# Altium schematic sheet HIGHSPEED_v0_NM.SchDoc
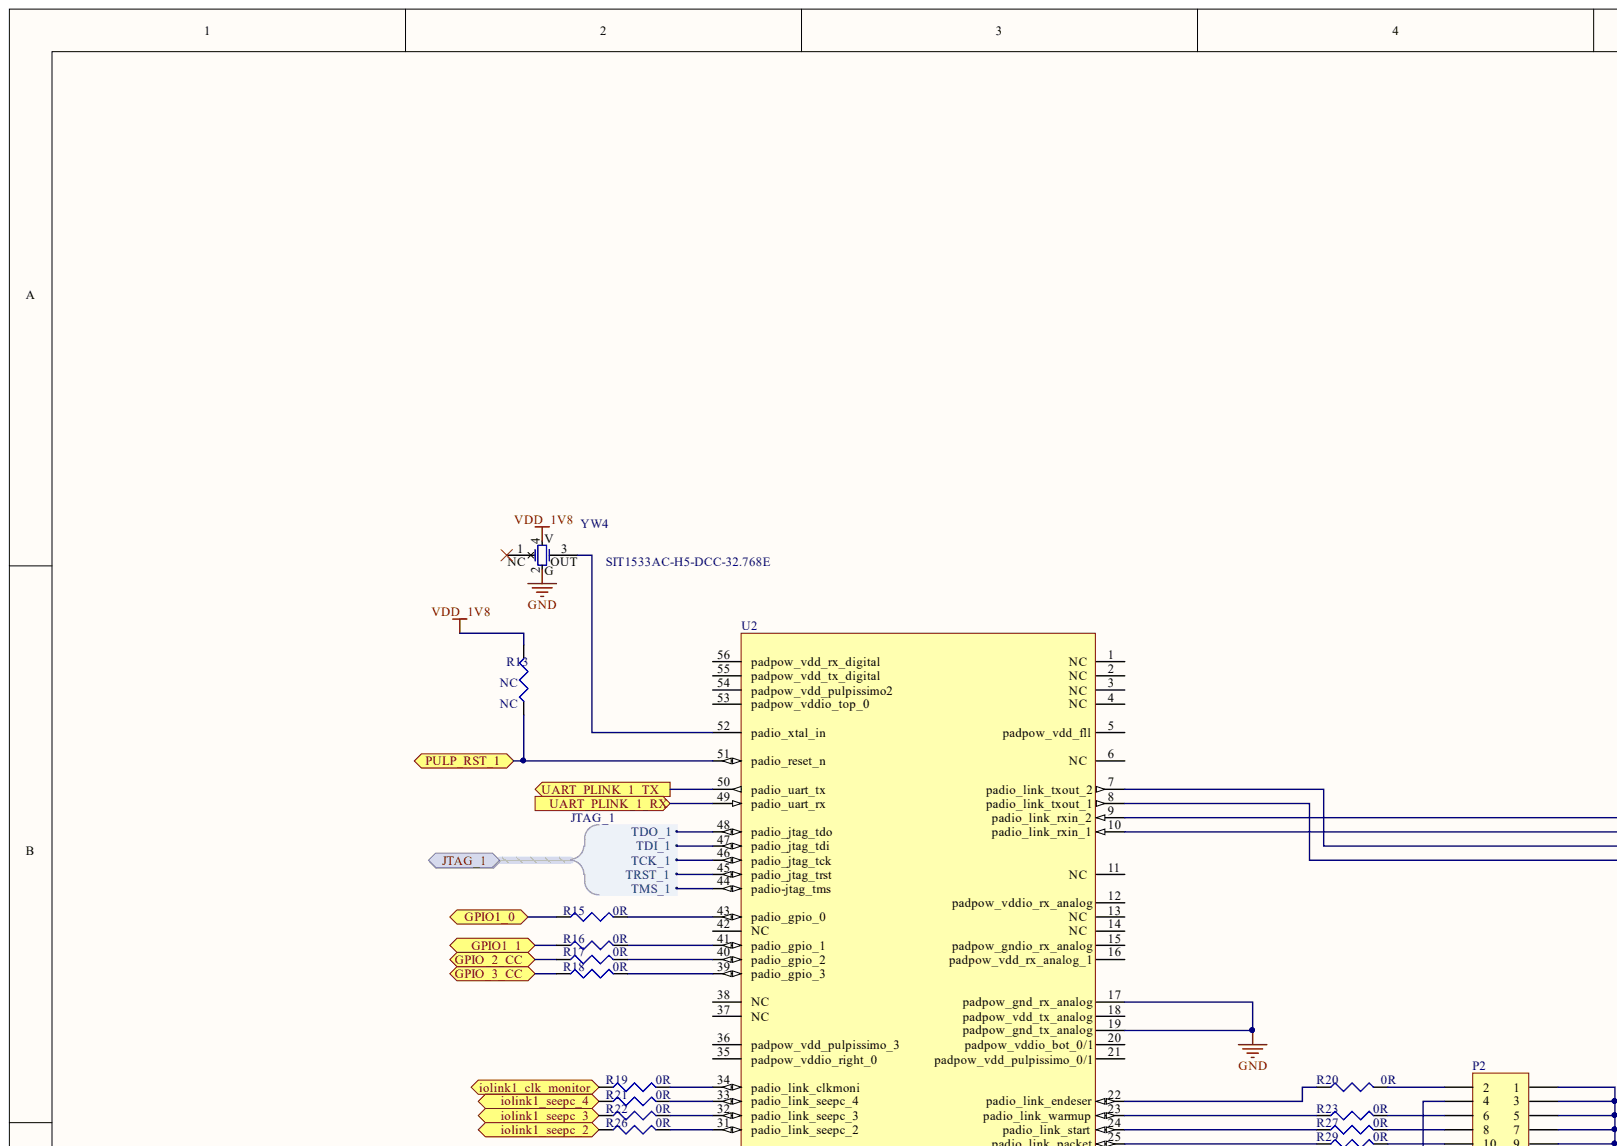
[diagram p0: HIGHSPEED_v0_NM.SchDoc, top left]
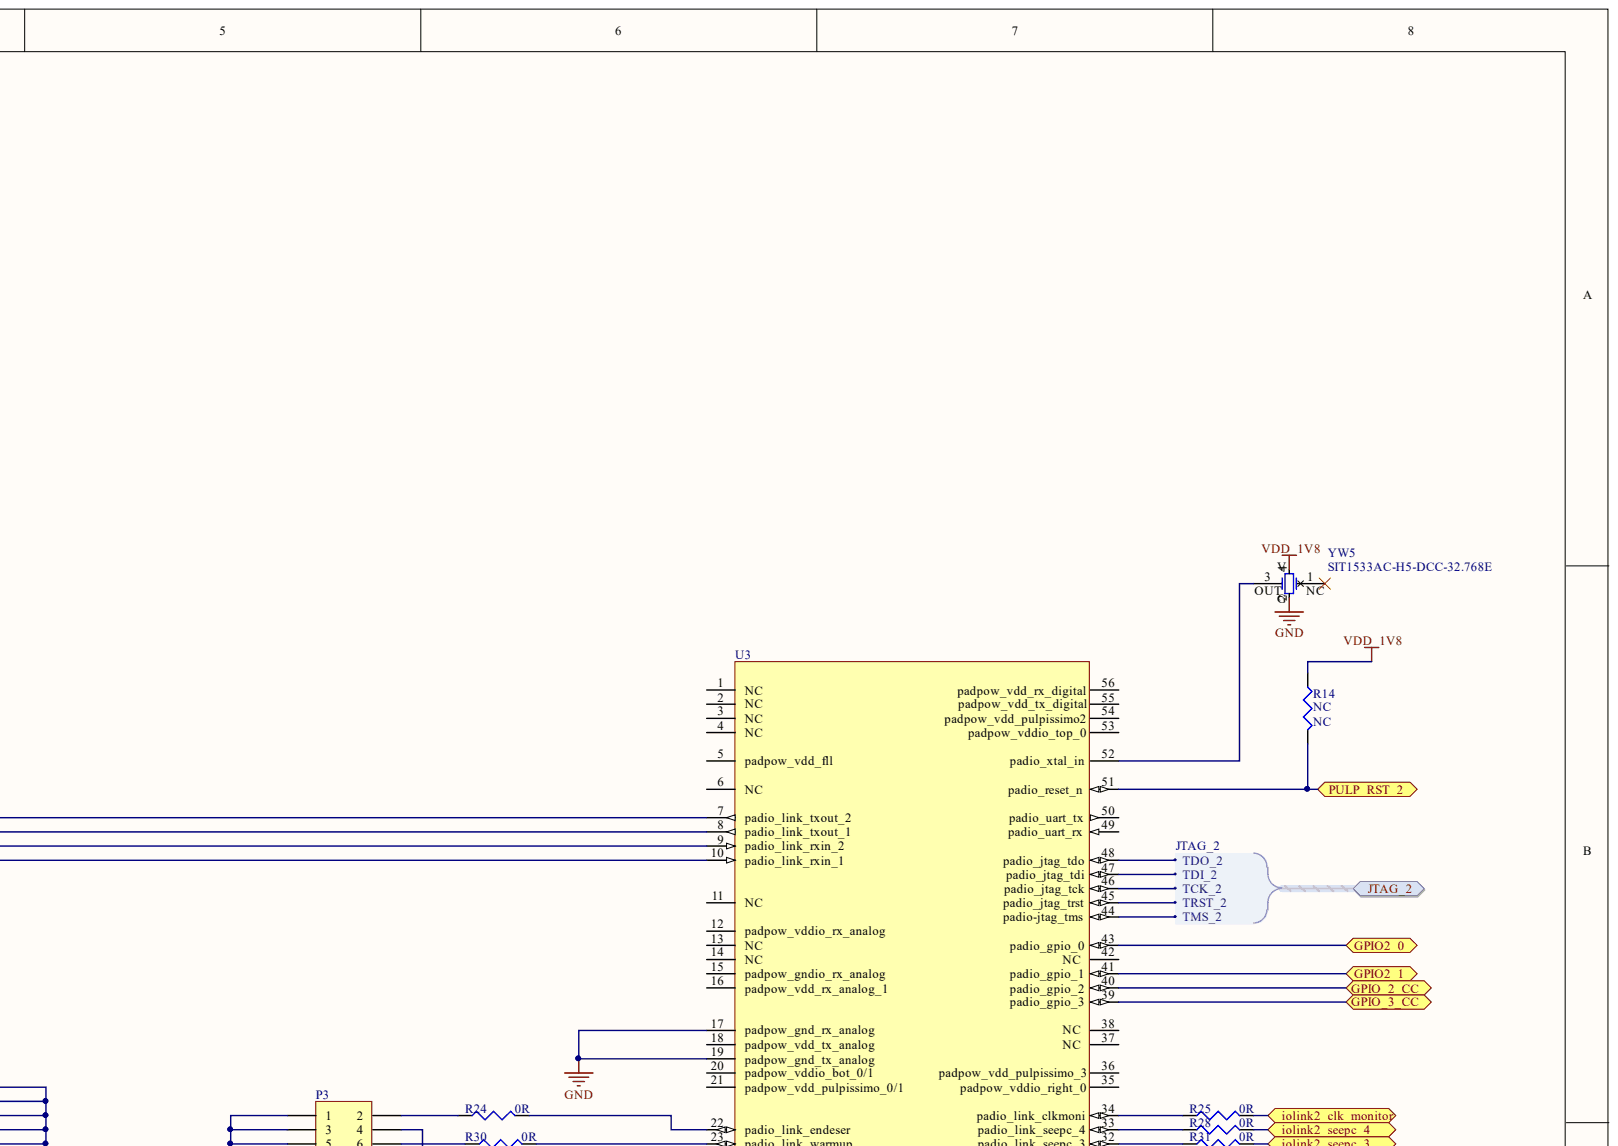
[diagram p1: HIGHSPEED_v0_NM.SchDoc, top right]
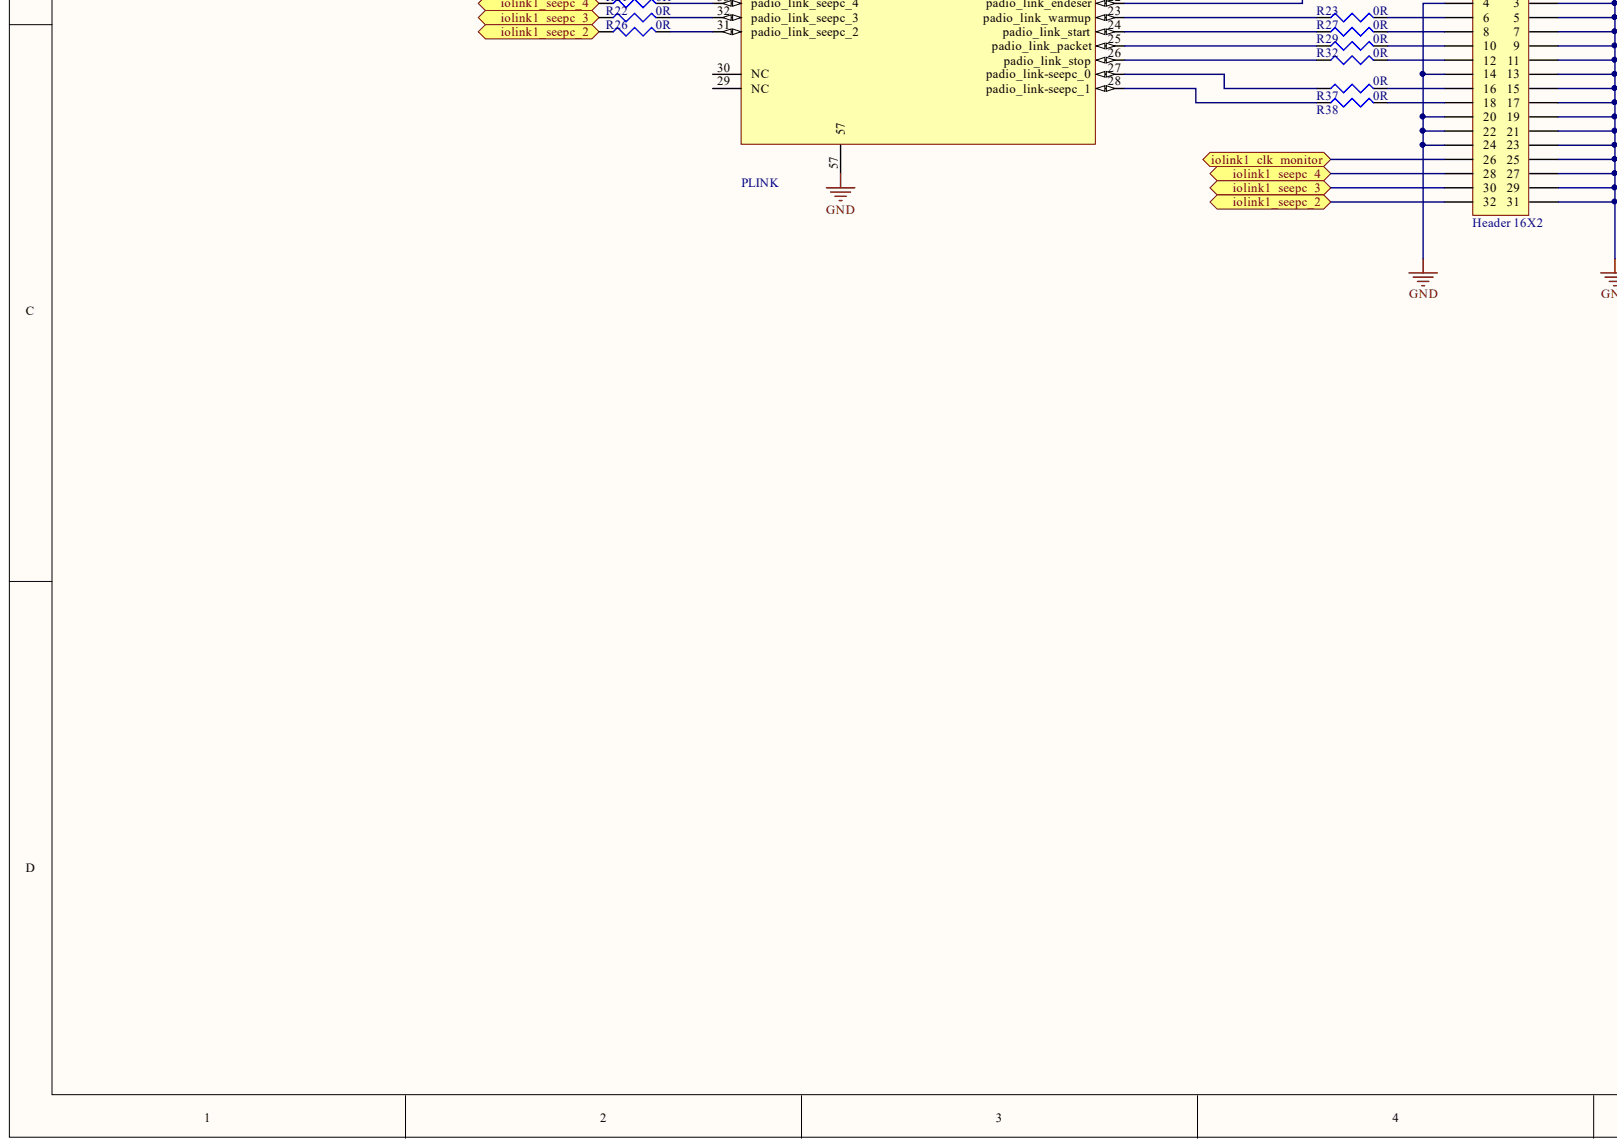
[diagram p2: HIGHSPEED_v0_NM.SchDoc, bottom left]
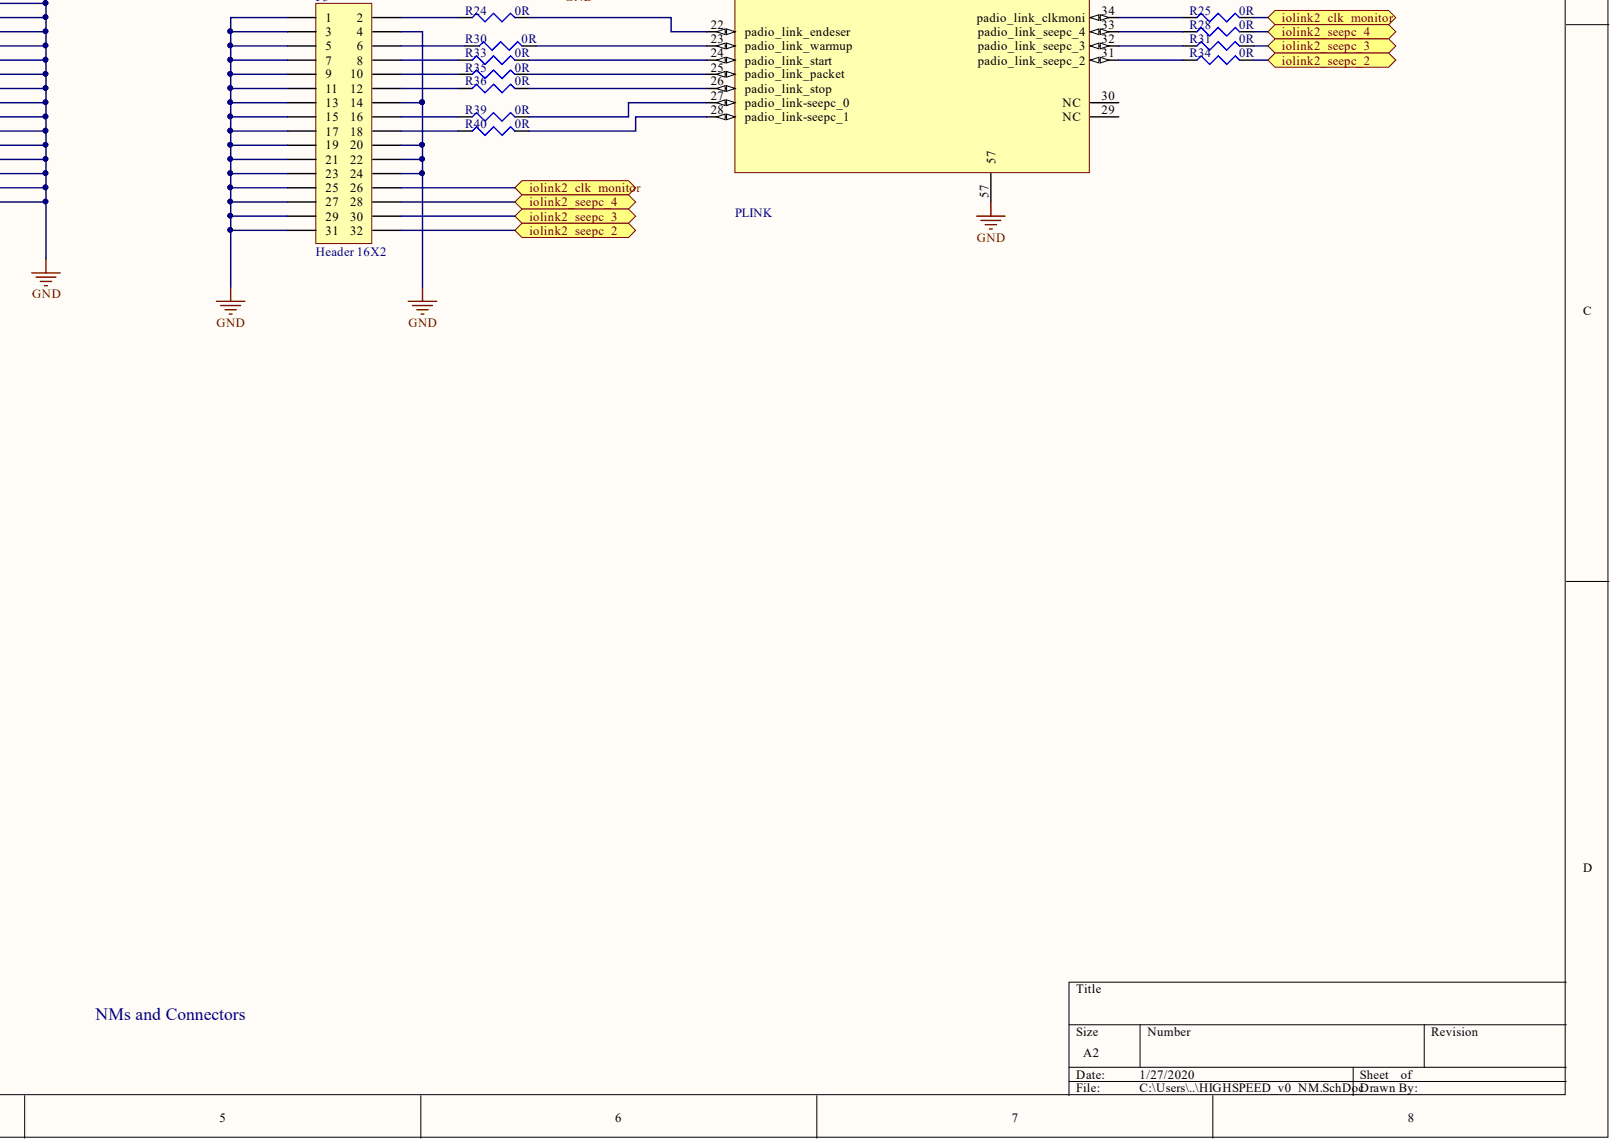
[diagram p3: HIGHSPEED_v0_NM.SchDoc, bottom right]

## Parts
P2  Header 16X2  [HDR2X16]
P3  Header 16X2  [HDR2X16]
R13  NC  [0402]
R14  NC  [0402]
R15  0R  [0402]
R16  0R  [0402]
R17  0R  [0402]
R18  0R  [0402]
R19  0R  [0402]
R20  0R  [0402]
R21  0R  [0402]
R22  0R  [0402]
R23  0R  [0402]
R24  0R  [0402]
R25  0R  [0402]
R26  0R  [0402]
R27  0R  [0402]
R28  0R  [0402]
R29  0R  [0402]
R30  0R  [0402]
R31  0R  [0402]
R32  0R  [0402]
R33  0R  [0402]
R34  0R  [0402]
R35  0R  [0402]
R36  0R  [0402]
R37  0R  [0402]
R38  0R  [0402]
R39  0R  [0402]
R40  0R  [0402]
U2  PLINK  [QFN56_M]
U3  PLINK  [QFN56_M]
YW4  SIT1533AC-H5-DCC-32.768E  [SiT1533-C]
YW5  SIT1533AC-H5-DCC-32.768E  [SiT1533-C]

## Ports
GPIO1_0, GPIO1_1, GPIO2_0, GPIO2_1, GPIO_2_CC, GPIO_3_CC, IOLINK1_CLK_MONITOR, IOLINK1_SEEPC_2, IOLINK1_SEEPC_3, IOLINK1_SEEPC_4, IOLINK2_CLK_MONITOR, IOLINK2_SEEPC_2, IOLINK2_SEEPC_3, IOLINK2_SEEPC_4, JTAG_1, JTAG_1.TCK_1, JTAG_1.TDI_1, JTAG_1.TDO_1, JTAG_1.TMS_1, JTAG_1.TRST_1, JTAG_2, JTAG_2.TCK_2, JTAG_2.TDI_2, JTAG_2.TDO_2, JTAG_2.TMS_2, JTAG_2.TRST_2, PULP_RST_1, PULP_RST_2, UART_PLINK_1_RX, UART_PLINK_1_TX

## Nets
GND: P2.1 P2.3 P2.4 P2.5 P2.7 P2.9 P2.11 P2.13 P2.14 P2.15 P2.17 P2.19 P2.20 P2.21 P2.22 P2.23 P2.24 P2.25 P2.27 P2.29 P2.31 P3.1 P3.3 P3.4 P3.5 P3.7 P3.9 P3.11 P3.13 P3.14 P3.15 P3.17 P3.19 P3.20 P3.21 P3.22 P3.23 P3.24 P3.25 P3.27 P3.29 P3.31 U2.17(padpow_gnd_rx_analog) U2.19(padpow_gnd_tx_analog) U2.57 U3.17(padpow_gnd_rx_analog) U3.19(padpow_gnd_tx_analog) U3.57 YW4.2 YW5.2
VDD_1V8: R13.2 R14.2 YW4.4 YW5.4
GPIO1_0: R15.2 ; port GPIO1_0
GPIO1_1: R16.2 ; port GPIO1_1
GPIO2_0: U3.43(padio_gpio_0) ; port GPIO2_0
GPIO2_1: U3.41(padio_gpio_1) ; port GPIO2_1
GPIO_2_CC: R17.2 U3.40(padio_gpio_2) ; port GPIO_2_CC
GPIO_3_CC: R18.2 U3.39(padio_gpio_3) ; port GPIO_3_CC
PULP_RST_1: R13.1 U2.51(padio_reset_n) ; port PULP_RST_1
PULP_RST_2: R14.1 U3.51(padio_reset_n) ; port PULP_RST_2
UART_PLINK_1_RX: U2.49(padio_uart_rx) ; port UART_PLINK_1_RX
UART_PLINK_1_TX: U2.50(padio_uart_tx) ; port UART_PLINK_1_TX
NetP2_2: P2.2 R20.2
NetP2_6: P2.6 R23.2
NetP2_8: P2.8 R27.2
NetP2_10: P2.10 R29.2
NetP2_12: P2.12 R32.2
NetP2_16: P2.16 R37.2
NetP2_18: P2.18 R38.2
NetP2_26: P2.26 R19.2 ; port IOLINK1_CLK_MONITOR
NetP2_28: P2.28 R21.2 ; port IOLINK1_SEEPC_4
NetP2_30: P2.30 R22.2 ; port IOLINK1_SEEPC_3
NetP2_32: P2.32 R26.2 ; port IOLINK1_SEEPC_2
NetP3_2: P3.2 R24.2
NetP3_6: P3.6 R30.2
NetP3_8: P3.8 R33.2
NetP3_10: P3.10 R35.2
NetP3_12: P3.12 R36.2
NetP3_16: P3.16 R39.2
NetP3_18: P3.18 R40.2
NetP3_26: P3.26 R25.1 ; port IOLINK2_CLK_MONITOR
NetP3_28: P3.28 R28.1 ; port IOLINK2_SEEPC_4
NetP3_30: P3.30 R31.1 ; port IOLINK2_SEEPC_3
NetP3_32: P3.32 R34.1 ; port IOLINK2_SEEPC_2
NetR15_1: R15.1 U2.43(padio_gpio_0)
NetR16_1: R16.1 U2.41(padio_gpio_1)
NetR17_1: R17.1 U2.40(padio_gpio_2)
NetR18_1: R18.1 U2.39(padio_gpio_3)
NetR19_1: R19.1 U2.34(padio_link_clkmoni)
NetR20_1: R20.1 U2.22(padio_link_endeser)
NetR21_1: R21.1 U2.33(padio_link_seepc_4)
NetR22_1: R22.1 U2.32(padio_link_seepc_3)
NetR23_1: R23.1 U2.23(padio_link_warmup)
NetR24_1: R24.1 U3.22(padio_link_endeser)
NetR25_2: R25.2 U3.34(padio_link_clkmoni)
NetR26_1: R26.1 U2.31(padio_link_seepc_2)
NetR27_1: R27.1 U2.24(padio_link_start)
NetR28_2: R28.2 U3.33(padio_link_seepc_4)
NetR29_1: R29.1 U2.25(padio_link_packet)
NetR30_1: R30.1 U3.23(padio_link_warmup)
NetR31_2: R31.2 U3.32(padio_link_seepc_3)
NetR32_1: R32.1 U2.26(padio_link_stop)
NetR33_1: R33.1 U3.24(padio_link_start)
NetR34_2: R34.2 U3.31(padio_link_seepc_2)
NetR35_1: R35.1 U3.25(padio_link_packet)
NetR36_1: R36.1 U3.26(padio_link_stop)
NetR37_1: R37.1 U2.27(padio_link-seepc_0)
NetR38_1: R38.1 U2.28(padio_link-seepc_1)
NetR39_1: R39.1 U3.27(padio_link-seepc_0)
NetR40_1: R40.1 U3.28(padio_link-seepc_1)
NetU2_7: U2.7(padio_link_txout_2) U3.9(padio_link_rxin_2)
NetU2_8: U2.8(padio_link_txout_1) U3.10(padio_link_rxin_1)
NetU2_9: U2.9(padio_link_rxin_2) U3.7(padio_link_txout_2)
NetU2_10: U2.10(padio_link_rxin_1) U3.8(padio_link_txout_1)
NetU2_44: U2.44(padio-jtag_tms) ; port JTAG_1
NetU2_45: U2.45(padio_jtag_trst) ; port JTAG_1
NetU2_46: U2.46(padio_jtag_tck) ; port JTAG_1
NetU2_47: U2.47(padio_jtag_tdi) ; port JTAG_1
NetU2_48: U2.48(padio_jtag_tdo) ; port JTAG_1
NetU2_52: U2.52(padio_xtal_in) YW4.3
NetU3_44: U3.44(padio-jtag_tms) ; port JTAG_2
NetU3_45: U3.45(padio_jtag_trst) ; port JTAG_2
NetU3_46: U3.46(padio_jtag_tck) ; port JTAG_2
NetU3_47: U3.47(padio_jtag_tdi) ; port JTAG_2
NetU3_48: U3.48(padio_jtag_tdo) ; port JTAG_2
NetU3_52: U3.52(padio_xtal_in) YW5.3

Not connected: U2 1(NC) 2(NC) 3(NC) 4(NC) 5(padpow_vdd_fll) 6(NC) 11(NC) 12(padpow_vddio_rx_analog) 13(NC) 14(NC) 15(padpow_gndio_rx_analog) 16(padpow_vdd_rx_analog_1) 18(padpow_vdd_tx_analog) 20(padpow_vddio_bot_0/1) 21(padpow_vdd_pulpissimo_0/1) 29(NC) 30(NC) 35(padpow_vddio_right_0) 36(padpow_vdd_pulpissimo_3) 37(NC) 38(NC) 42(NC) 53(padpow_vddio_top_0) 54(padpow_vdd_pulpissimo2) 55(padpow_vdd_tx_digital) 56(padpow_vdd_rx_digital); U3 1(NC) 2(NC) 3(NC) 4(NC) 5(padpow_vdd_fll) 6(NC) 11(NC) 12(padpow_vddio_rx_analog) 13(NC) 14(NC) 15(padpow_gndio_rx_analog) 16(padpow_vdd_rx_analog_1) 18(padpow_vdd_tx_analog) 20(padpow_vddio_bot_0/1) 21(padpow_vdd_pulpissimo_0/1) 29(NC) 30(NC) 35(padpow_vddio_right_0) 36(padpow_vdd_pulpissimo_3) 37(NC) 38(NC) 42(NC) 49(padio_uart_rx) 50(padio_uart_tx) 53(padpow_vddio_top_0) 54(padpow_vdd_pulpissimo2) 55(padpow_vdd_tx_digital) 56(padpow_vdd_rx_digital); YW4 1; YW5 1

## Library data of the parts
P2 P3 = Header 16X2: Header, 16-Pin, Dual row; Component Kind: Standard; LatestRevisionDate: 17-Jul-2002; LatestRevisionNote: Re-released for DXP Platform.; Library Name: Miscellaneous Connectors.IntLib; Signal Integrity: Connector; Publisher: Altium Limited
R13-R40 = Res3: Resistor; Component Kind: Standard; LatestRevisionDate: 29-May-2009; LatestRevisionNote: IPC-7351 Footprint Added.; Library Name: Miscellaneous Devices.IntLib; PackageDescription: Chip Resistor; PackageReference: J1-0603; Published: 8-Jun-2000; Signal Integrity: Res; Simulation: RESISTOR; Publisher: Altium Limited
  R13 R14: Value: NC
  R15-R40: Value: 0R
YW4 YW5 = SIT-OSC32KHZ-SIT1533AC-H5-DCC-32.768: 20 ppm, Ultra-Low Power 32.768 kHz Quartz XTAL Replacement; Current - Supply (max): 1.3uA; Component Kind: Standard; ComponentLink1Description: Datasheet; ComponentLink1URL: http://www.sitime.com/products/datasheets/sit1533/SiT1533-datasheet.pdf; Frequency: 32.768kHz; Supplier 1: Digi-Key; Supplier 2: Digi-Key; Supplier 3: Digi-Key; Supplier 4: Digi-Key; Supplier 5: Digi-Key; Supplier 6: Digi-Key; Supplier 7: Digi-Key; Supplier 8: Digi-Key; Supplier Part Number 1: 1473-1515-2-ND; Supplier Part Number 2: 1473-1515-1-ND; Supplier Part Number 3: 1473-1515-6-ND; Supplier Part Number 4: 1473-1516-2-ND; Supplier Part Number 5: 1473-1516-1-ND; Supplier Part Number 6: 1473-1516-6-ND; Supplier Part Number 7: SIT1533AC-H5-DCC-32.768S-ND; Supplier Part Number 8: SIT1533AC-H5-DCC-32.768D-ND; Library Name: smach_lib.intlib
U2 U3 = PLINK: Component Kind: Standard; Description: PLINK; Library Name: plink.SchLib
